# Altium schematic sheet CurrentS_2.SchDoc
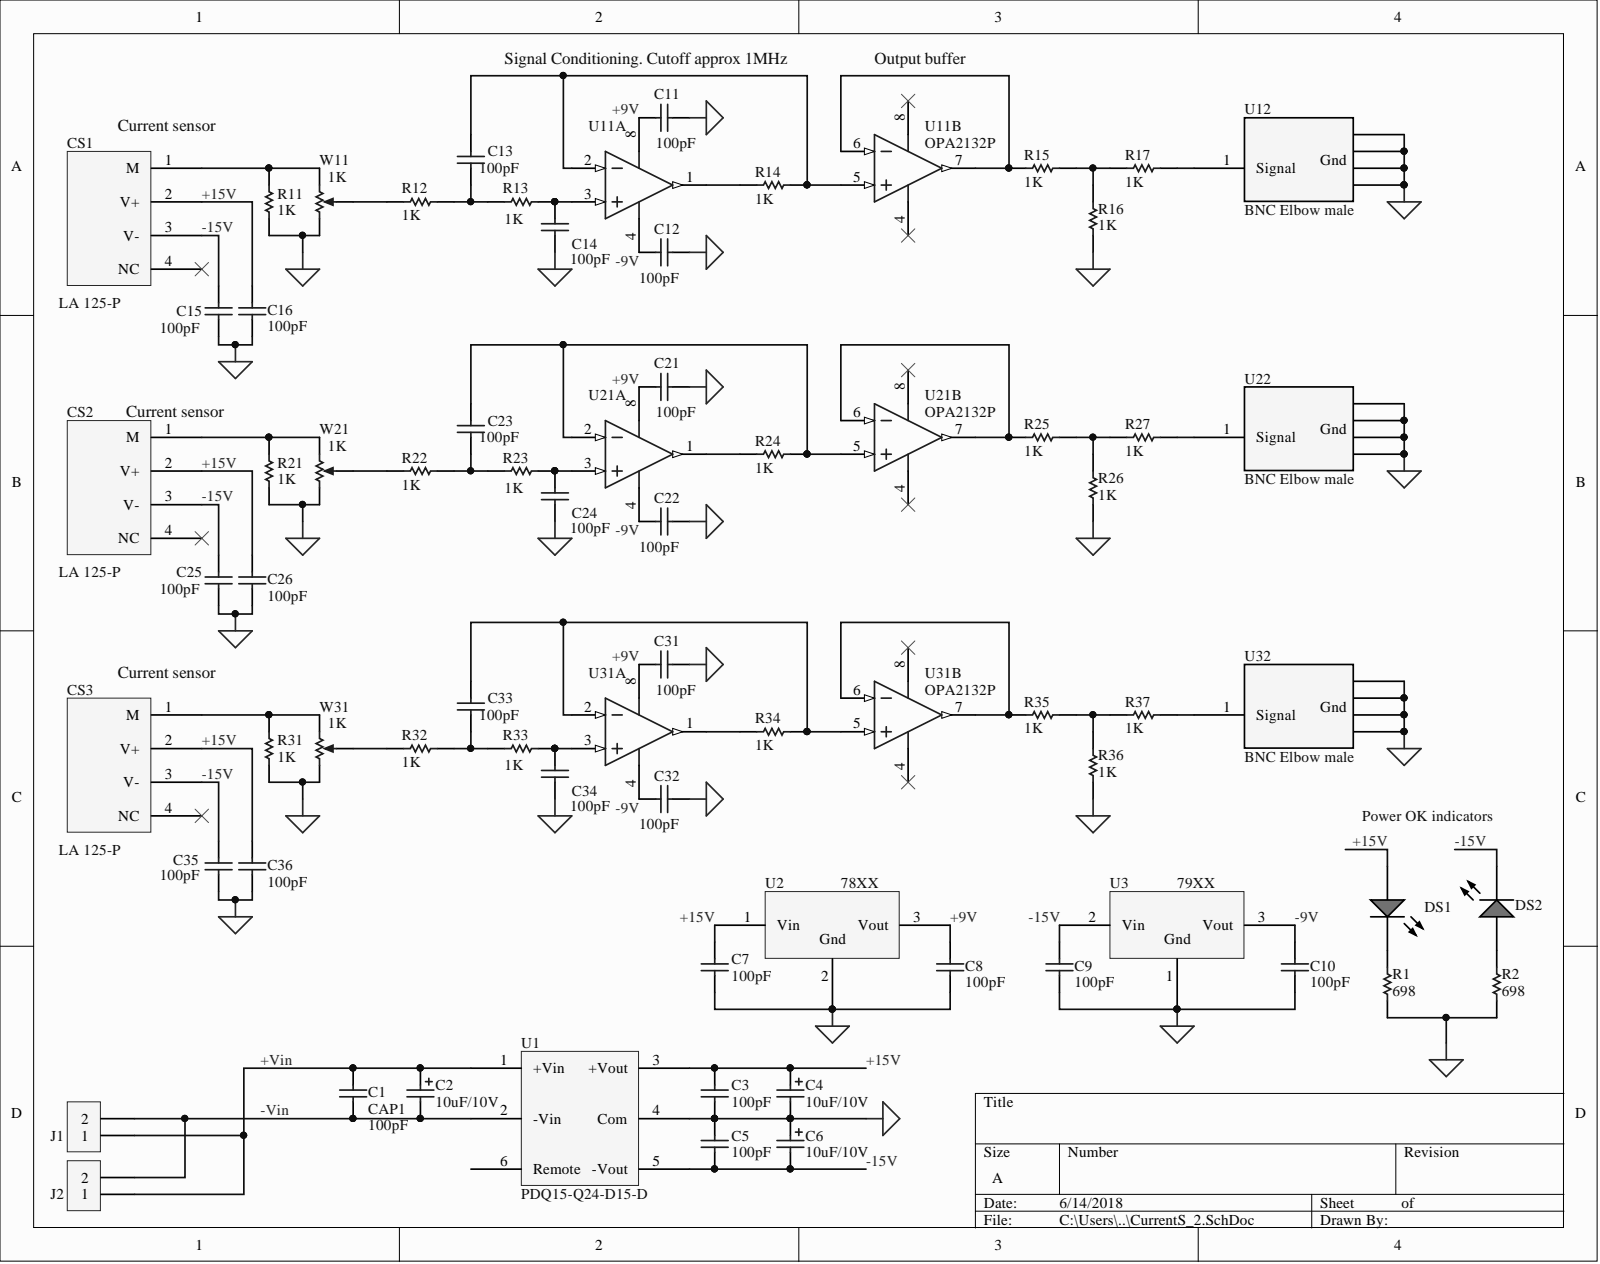
[diagram p0: CurrentS_2.SchDoc]

## Parts
C1  CAP1  value 100pF  [1206]
C2  value 10uF/10V  [CAP.2/.5]  (hidden comment: ECAP)
C3  value 100pF  [1206]  (hidden comment: CAP1)
C4  value 10uF/10V  [CAP.2/.5]  (hidden comment: ECAP)
C5  value 100pF  [1206]  (hidden comment: CAP1)
C6  value 10uF/10V  [CAP.2/.5]  (hidden comment: ECAP)
C7  value 100pF  [1206]  (hidden comment: CAP1)
C8  value 100pF  [1206]  (hidden comment: CAP1)
C9  value 100pF  [1206]  (hidden comment: CAP1)
C10  value 100pF  [1206]  (hidden comment: CAP1)
C11  value 100pF  [1206]  (hidden comment: CAP1)
C12  value 100pF  [1206]  (hidden comment: CAP1)
C13  value 100pF  [1206]  (hidden comment: CAP1)
C14  value 100pF  [1206]  (hidden comment: CAP1)
C15  value 100pF  [1206]  (hidden comment: CAP1)
C16  value 100pF  [1206]  (hidden comment: CAP1)
C21  value 100pF  [1206]  (hidden comment: CAP1)
C22  value 100pF  [1206]  (hidden comment: CAP1)
C23  value 100pF  [1206]  (hidden comment: CAP1)
C24  value 100pF  [1206]  (hidden comment: CAP1)
C25  value 100pF  [1206]  (hidden comment: CAP1)
C26  value 100pF  [1206]  (hidden comment: CAP1)
C31  value 100pF  [1206]  (hidden comment: CAP1)
C32  value 100pF  [1206]  (hidden comment: CAP1)
C33  value 100pF  [1206]  (hidden comment: CAP1)
C34  value 100pF  [1206]  (hidden comment: CAP1)
C35  value 100pF  [1206]  (hidden comment: CAP1)
C36  value 100pF  [1206]  (hidden comment: CAP1)
CS1  LA 125-P  [LA 125-P]
CS2  LA 125-P  [LA 125-P]
CS3  LA 125-P  [LA 125-P]
DS1  SML-LXT0805GW-TR  [LUMX-SML-LXT0805_V]  (value not drawn)
DS2  SML-LXT0805GW-TR  [LUMX-SML-LXT0805_V]  (value not drawn)
J1  CON2  [3100-8-102-01]  (value not drawn)
J2  CON2  [3100-8-102-01]  (value not drawn)
R1  value 698  [0805]  (hidden comment: Res1)
R2  value 698  [0805]  (hidden comment: Res1)
R11  value 1K  [0805]  (hidden comment: Res1)
R12  value 1K  [0805]  (hidden comment: Res1)
R13  value 1K  [0805]  (hidden comment: Res1)
R14  value 1K  [0805]  (hidden comment: Res1)
R15  value 1K  [0805]  (hidden comment: Res1)
R16  value 1K  [0805]  (hidden comment: Res1)
R17  value 1K  [0805]  (hidden comment: Res1)
R21  value 1K  [0805]  (hidden comment: Res1)
R22  value 1K  [0805]  (hidden comment: Res1)
R23  value 1K  [0805]  (hidden comment: Res1)
R24  value 1K  [0805]  (hidden comment: Res1)
R25  value 1K  [0805]  (hidden comment: Res1)
R26  value 1K  [0805]  (hidden comment: Res1)
R27  value 1K  [0805]  (hidden comment: Res1)
R31  value 1K  [0805]  (hidden comment: Res1)
R32  value 1K  [0805]  (hidden comment: Res1)
R33  value 1K  [0805]  (hidden comment: Res1)
R34  value 1K  [0805]  (hidden comment: Res1)
R35  value 1K  [0805]  (hidden comment: Res1)
R36  value 1K  [0805]  (hidden comment: Res1)
R37  value 1K  [0805]  (hidden comment: Res1)
U1  PDQ15-Q24-D15-D  [PDQ15-Q24-D15-D]
U2  78XX  [TO-220]
U3  79XX  [TO-220]
U11  OPA2132P  [P0008A]  (parts A,B)
U12  BNC Elbow male  [5-1814400-1]
U21  OPA2132P  [P0008A]  (parts A,B)
U22  BNC Elbow male  [5-1814400-1]
U31  OPA2132P  [P0008A]  (parts A,B)
U32  BNC Elbow male  [5-1814400-1]
W11  value 1K  [VR5]  (hidden comment: RPot1)
W21  value 1K  [VR5]  (hidden comment: RPot1)
W31  value 1K  [VR5]  (hidden comment: RPot1)

## Nets
GND: C3.1 C4.2 C5.2 C6.1 C7.1 C8.1 C9.1 C10.1 C11.1 C12.1 C14.1 C15.1 C16.1 C21.1 C22.1 C24.1 C25.1 C26.1 C31.1 C32.1 C34.1 C35.1 C36.1 R1.1 R2.1 R11.1 R16.2 R21.1 R26.2 R31.1 R36.2 U1.4(Com) U2.2 U3.1 U12.2 U12.3 U12.4(Gnd) U12.5 U22.2 U22.3 U22.4 U22.5 U32.2 U32.3 U32.4 U32.5 W11.1 W21.1 W31.1
+9V: C8.2 C11.2 C21.2 C31.2 U2.3 U11.8 U21.8 U31.8
+15V: C3.2 C4.1 C7.2 C16.2 C26.2 C36.2 CS1.2(V+) CS2.2(V+) CS3.2(V+) DS1.1 U1.3(+Vout) U2.1
+Vin: C1.2 C2.1 J1.1 J2.1 U1.1(+Vin)
-9V: C10.2 C12.2 C22.2 C32.2 U3.3 U11.4 U21.4 U31.4
-15V: C5.1 C6.2 C9.2 C15.2 C25.2 C35.2 CS1.3(V-) CS2.3(V-) CS3.3(V-) DS2.2 U1.5(-Vout) U3.2
-Vin: C1.1 C2.2 J1.2 J2.2 U1.2(-Vin)
NetC13_1: C13.1 R12.1 R13.2
NetC13_2: C13.2 R14.1 U11.2 U11.5
NetC14_2: C14.2 R13.1 U11.3
NetC23_1: C23.1 R22.1 R23.2
NetC23_2: C23.2 R24.1 U21.2 U21.5
NetC24_2: C24.2 R23.1 U21.3
NetC33_1: C33.1 R32.1 R33.2
NetC33_2: C33.2 R34.1 U31.2 U31.5
NetC34_2: C34.2 R33.1 U31.3
NetCS1_1: CS1.1(M) R11.2 W11.3
NetCS2_1: CS2.1(M) R21.2 W21.3
NetCS3_1: CS3.1(M) R31.2 W31.3
NetDS1_2: DS1.2 R1.2
NetDS2_1: DS2.1 R2.2
NetR12_2: R12.2 W11.2
NetR14_2: R14.2 U11.1
NetR15_1: R15.1 R16.1 R17.2
NetR15_2: R15.2 U11.6 U11.7
NetR17_1: R17.1 U12.1(Signal)
NetR22_2: R22.2 W21.2
NetR24_2: R24.2 U21.1
NetR25_1: R25.1 R26.1 R27.2
NetR25_2: R25.2 U21.6 U21.7
NetR27_1: R27.1 U22.1(Signal)
NetR32_2: R32.2 W31.2
NetR34_2: R34.2 U31.1
NetR35_1: R35.1 R36.1 R37.2
NetR35_2: R35.2 U31.6 U31.7
NetR37_1: R37.1 U32.1(Signal)

Not connected: CS1 4(NC); CS2 4(NC); CS3 4(NC); U1 6(Remote)

## Library data of the parts
C1 C3 C5 C7-C10 C12-C16 C21-C26 C32-C36 = CAP1: Capacitor; Manufacturer: Generic Components; Class I: Passive; Class II: Capacitor; Component Kind: Standard; Published: 8-Jun-2000; Revision: July-2002: Re-released for DXP Platform.; Library Name: ERL_Components.IntLib; Publisher: Altium Limited
C2 C4 C6 = ECAP: Polarized Capacitor (Surface Mount); Manufacturer: Generic Components; Class I: Passive; Class II: Capacitor; Component Kind: Standard; Published: 8-Jun-2000; Revision: July-2002: Re-released for DXP Platform.; Library Name: ERL_Components.IntLib; Publisher: Altium Limited
C11 C31 = CAP1: Capacitor; Manufacturer: Generic Components; Class I: Passive; Value: 100pF; Class II: Capacitor; Component Kind: Standard; Published: 8-Jun-2000; Revision: July-2002: Re-released for DXP Platform.; Library Name: ERL_Components.IntLib; Publisher: Altium Limited
CS1-CS3 = LA 125-P: Hall-effect current transducer from LEM; Component Kind: Standard; Supplier 1: Allied Electronics; Supplier Part Number 1: R1039558; Library Name: KyleCustomModels.IntLib
DS1 DS2 = CMP-1724-00001-1: LED, GREEN, 565 nm, 2 V, -25 to 80 degC, 2-Pin SMD, RoHS, Tape and Reel; Case\/Package: 0805; Case Code (Imperial): 0805; Case Code (Metric): 2012; Component Kind: Standard; ComponentLink1Description: Manufacturer URL; ComponentLink1URL: http://www.lumexinstruments.com/; ComponentLink2Description: Datasheet; ComponentLink2URL: http://www.lumex.com/content/files/ProductAttachment/SML-LXT0805GW-TR.pdf; DatasheetVersion: Rev. F, 09/2009; Length: 2 mm; Library Name: Altium Content Vault; Max Operating Temperature: 85 degC; Min Operating Temperature: -25 degC; Mount: Surface Mount; Mounting Technology: Surface Mount; PackageDescription: 2-Pin Surface Mount Device, Body 2 x 1.25 mm; Package Quantity: 4000; PackageReference: SML-LXT0805; Packaging: Tape and Reel; REACH SVHC: No SVHC; RoHSCompliant: true; Width: 1.25 mm
J1 J2 = CON2: Connector; Component Kind: Standard; Library Name: ERL_Components.IntLib
R1 R2 R11-R17 R21-R27 R31-R37 = Res1: Resistor/0.1W; Manufacturer: Generic Components; Class I: Passive; Class II: Resistor; Component Kind: Standard; Published: 8-Jun-2000; Revision: July-2002: Re-released for DXP Platform.; Library Name: ERL_Components.IntLib; Publisher: Altium Limited
U1 = PDQ15-Q24-D15-D: Isolated DC/DC Converters 15W 9-36Vin +/-15V +/-500mA Iso Reg DIP; Component Kind: Standard; Library Name: KyleCustomModels.IntLib
U2 = 78XX: 1A linear positive voltage regulator; Component Kind: Standard; Library Name: KyleCustomModels.IntLib
U11 U21 U31 = CMP-0271-00384-3: High Speed FET-Input Operational Amplifier, 5 to 36 V, -40 to 125 degC, 8-pin DIP (P8), Green (RoHS & no Sb/Br); Manufacturer: Texas Instruments; CMRR(Min)(dB): 96; GBW(Typ)(MHz): 8; IIB(Max)(pA): 50; Iq per channel(Max)(mA): 4.8; Number of Channels: 2; Packing: TUBE; Rating: Catalog; Slew Rate(Typ)(V/us): 20; Sub Family: High Supply Voltage Amplifier (>=30V), Low Noise Amp (<=10nV/rtHz), Low Offset Voltage Amplifier (<=500uV), Precision Amplifier; Total Supply Voltage(Max)(+5V is 5, +/-5V is 10): 36; Total Supply Voltage(Min)(+5V is 5, +/-5V is 10): 5; Vio (25C)(Max)(mV): 0.5; Code_JEDEC: MS-001; Component Kind: Standard; ComponentLink1Description: Manufacturer URL; ComponentLink1URL: http://www.ti.com/; ComponentLink2Description: Datasheet; ComponentLink2URL: http://www.ti.com/lit/ds/symlink/opa2132.pdf; ComponentLink3Description: Package Specification; ComponentLink3URL: http://www.ti.com/litv/pdf/mpdi001b; DatasheetVersion: SBOS054A; Eco-Plan: Green (RoHS & no Sb/Br); Library Name: Altium Content Vault; Mounting Technology: Through Hole; Offset Drift(Typ)(uV/C): 2; PackageDescription: 8-Pin Plastic In-Line, Body 9.59 x 6.35 mm, Pitch 2.54 mm; PackageReference: P0008A; PackageVersion: 4040082/E, 04/2010; PartNumber: OPA2132P
U12 U22 U32 = BNC Elbow male: BNC Low Profile Elbow Connector; Manufacturer_Part_Number: 5-1634513-1; Vendor: Tyco Electronics; Component Kind: Standard; RefDes: RefDes; Type: Type; Value: Value; Copyright: Copyright (C) 2015 Accelerated Designs. All rights reserved; Library Name: ERL_Components.IntLib
W11 W21 W31 = RPot1: Potentiometer; Manufacturer: Generic Components; Class I: Passive; Set Position: 0.5; Class II: Potentiometer; Component Kind: Standard; Published: 8-Jun-2000; Revision: July-2002: Re-released for DXP Platform.; Simulation: POT; Library Name: ERL_Components.IntLib; Publisher: Altium Limited
U3 = 79XX: Component Kind: Standard; Library Name: KyleCustomModels.IntLib
